# Altium schematic sheet Sheet7.SchDoc
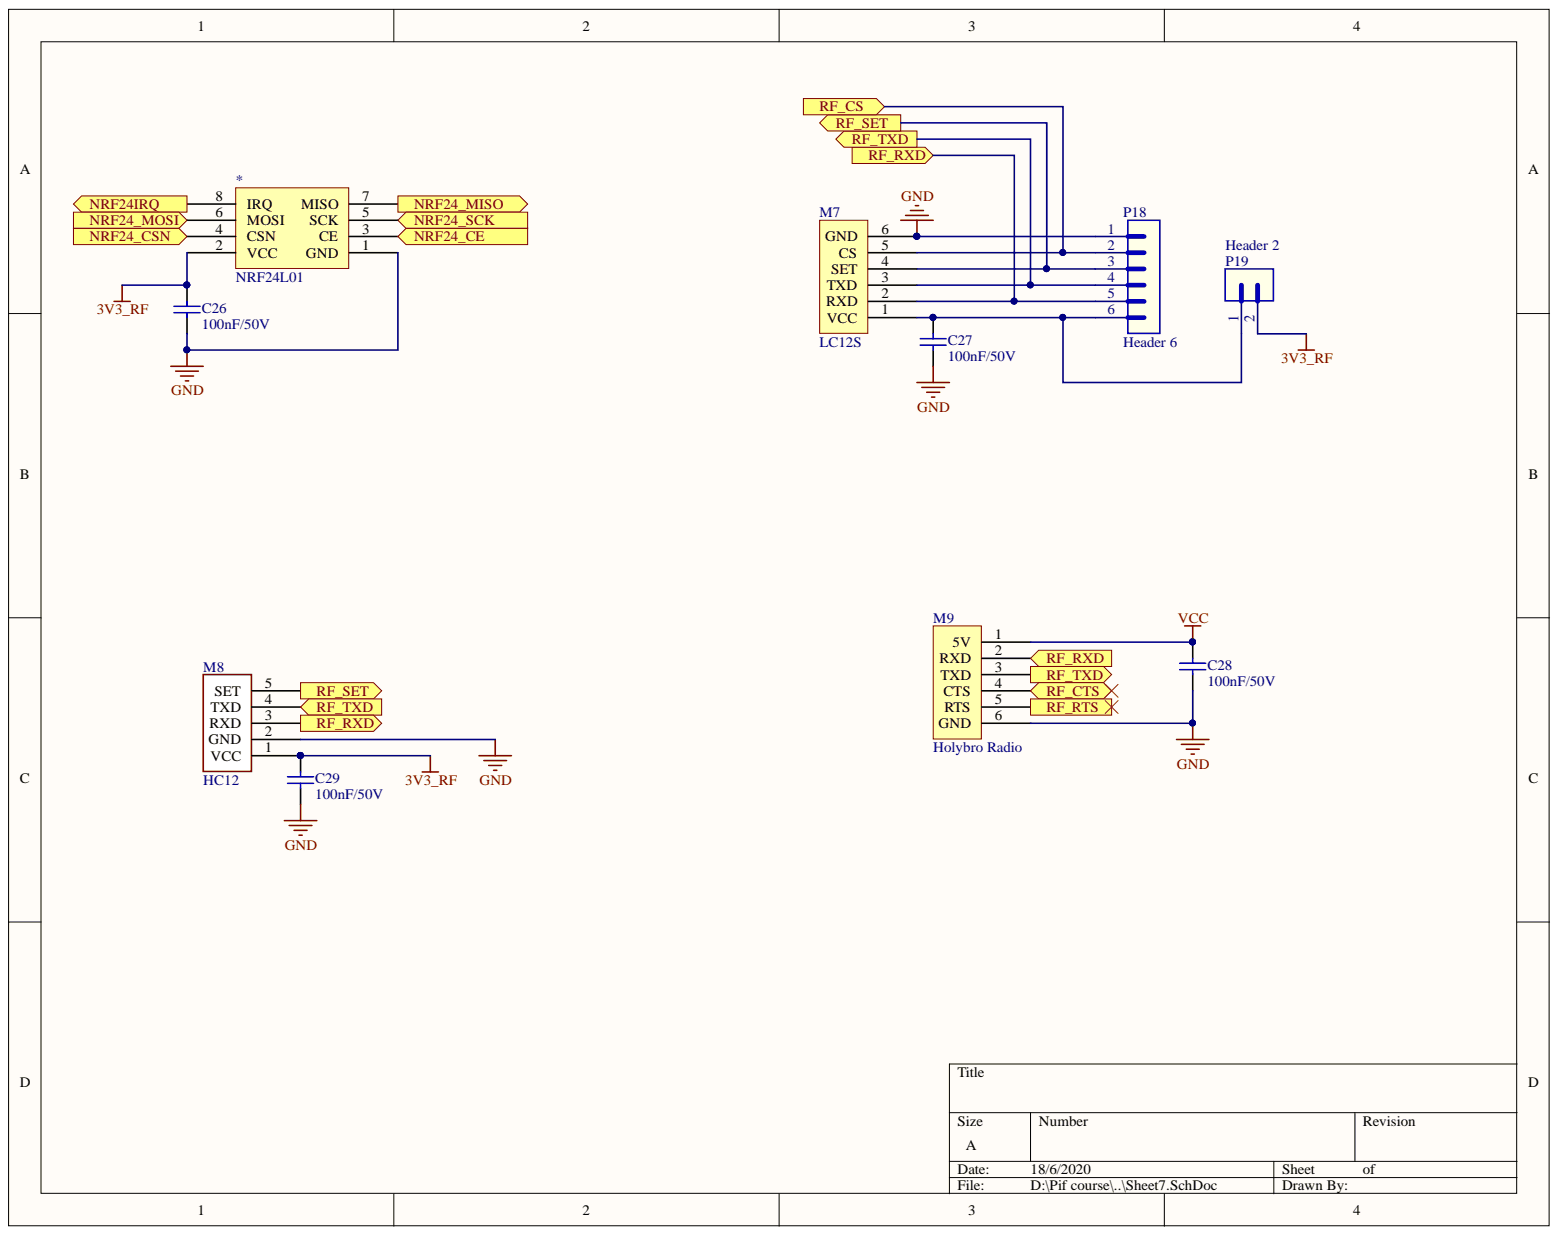
[diagram p0: Sheet7.SchDoc]

## Parts
*  NRF24L01  [NRF24L01]
C26  value 100nF/50V  [CAP-SM-0805]  (hidden comment: Cap-1nF-50V-0805)
C27  value 100nF/50V  [CAP-SM-0805]  (hidden comment: Cap-1nF-50V-0805)
C28  value 100nF/50V  [CAP-SM-0805]  (hidden comment: Cap-1nF-50V-0805)
C29  value 100nF/50V  [CAP-SM-0805]  (hidden comment: Cap-1nF-50V-0805)
M7  LC12S  [LC12S]
M8  HC12  [HC12]
M9  Holybro Radio  [Holybro]
P18  Header 6  [JP6]
P19  Header 2  [JP2]

## Ports
NRF24_CE, NRF24_CSN, NRF24_MISO, NRF24_MOSI, NRF24_SCK, NRF24IRQ, RF_CS, RF_CTS, RF_RTS, RF_RXD, RF_SET, RF_TXD

## Nets
3V3_RF: *.2(VCC) C26.2 C29.2 M8.1(VCC) P19.2
GND: *.1(GND) C26.1 C27.1 C28.1 C29.1 M7.6(GND) M8.2(GND) M9.6(GND) P18.1
VCC: C28.2 M9.1(5V)
NRF24_CE: *.3(CE) ; port NRF24_CE
NRF24_CSN: *.4(CSN) ; port NRF24_CSN
NRF24_MISO: *.7(MISO) ; port NRF24_MISO
NRF24_MOSI: *.6(MOSI) ; port NRF24_MOSI
NRF24_SCK: *.5(SCK) ; port NRF24_SCK
NRF24IRQ: *.8(IRQ) ; port NRF24IRQ
RF_CS: M7.5(CS) P18.2 ; port RF_CS
RF_CTS: M9.4(CTS) ; port RF_CTS
RF_RTS: M9.5(RTS) ; port RF_RTS
RF_RXD: M7.2(RXD) M8.3(RXD) M9.2(RXD) P18.5 ; port RF_RXD
RF_SET: M7.4(SET) M8.5(SET) P18.3 ; port RF_SET
RF_TXD: M7.3(TXD) M8.4(TXD) M9.3(TXD) P18.4 ; port RF_TXD
NetC27_2: C27.2 M7.1(VCC) P18.6 P19.1

## Library data of the parts
* = NRF24L01: Local supply: Hshop; Component Kind: Standard; Library Name: Schlib1.SchLib; Local supply link: https://lastminuteengineers.com/nrf24l01-arduino-wireless-communication/
C26-C29 = Cap-1nF-50V-0805: CAP CER 1000PF 100V X7R 0805; Manufacturer: Johanson Dielectrics Inc.; Manufacturer Part Number: 101X15W102MV4E; Component Kind: Standard; Library Name: Capacitor.SchLib; Local Supplier: thegioiic; Local Supplier Link: https://thegioiic.com/products/tu-1nf-50v-0805; Supplier 1: Digikey; Supplier 1 Link: https://www.digikey.com/product-detail/en/johanson-dielectrics-inc/101X15W102MV4E/709-1335-1-ND/2327235; Supplier 1 Part Number: 709-1335-1-ND
M7 = LC12S: Local supply: HShop; Component Kind: Standard; Library Name: Schlib1.SchLib; Local supply link: https://hshop.vn/products/mach-thu-phat-rf-uart-lc12s-2-4ghz
M8 = HC12: Local supply: Hshop; Local supply number: HS000897; Component Kind: Standard; Library Name: Integrated_Library.IntLib; Local supply link: https://hshop.vn/products/mach-thu-phat-rf-uart-si4463-433mhzkhoang-coch-1km
M9 = Holybro: Component Kind: Standard; Library Name: Integrated_Library.IntLib; Supplier: https://www.lazada.vn/products/ld-holybro-433mhz-915-mhz-500-mw-thu-phat-vo-tuyen-xa-bo-v3-cho-pixhawk-4-dieu-khien-chuyen-bay-i430804435-s768474304.html?spm=a2o4n.searchlist.list.4.25396b19yIS9XO&search=1
P18 = Header 6: Header, 6-Pin; Component Kind: Standard; LatestRevisionDate: 17-Jul-2002; LatestRevisionNote: Re-released for DXP Platform.; Library Name: Header-connector.SchLib; Publisher: Altium Limited
P19 = Header 2: Header, 2-Pin; Component Kind: Standard; LatestRevisionDate: 17-Jul-2002; LatestRevisionNote: Re-released for DXP Platform.; Library Name: Header-connector.SchLib; Publisher: Altium Limited
